# Altium schematic sheet Motor_External_Connectors.SchDoc(Motor_External_Connectors)
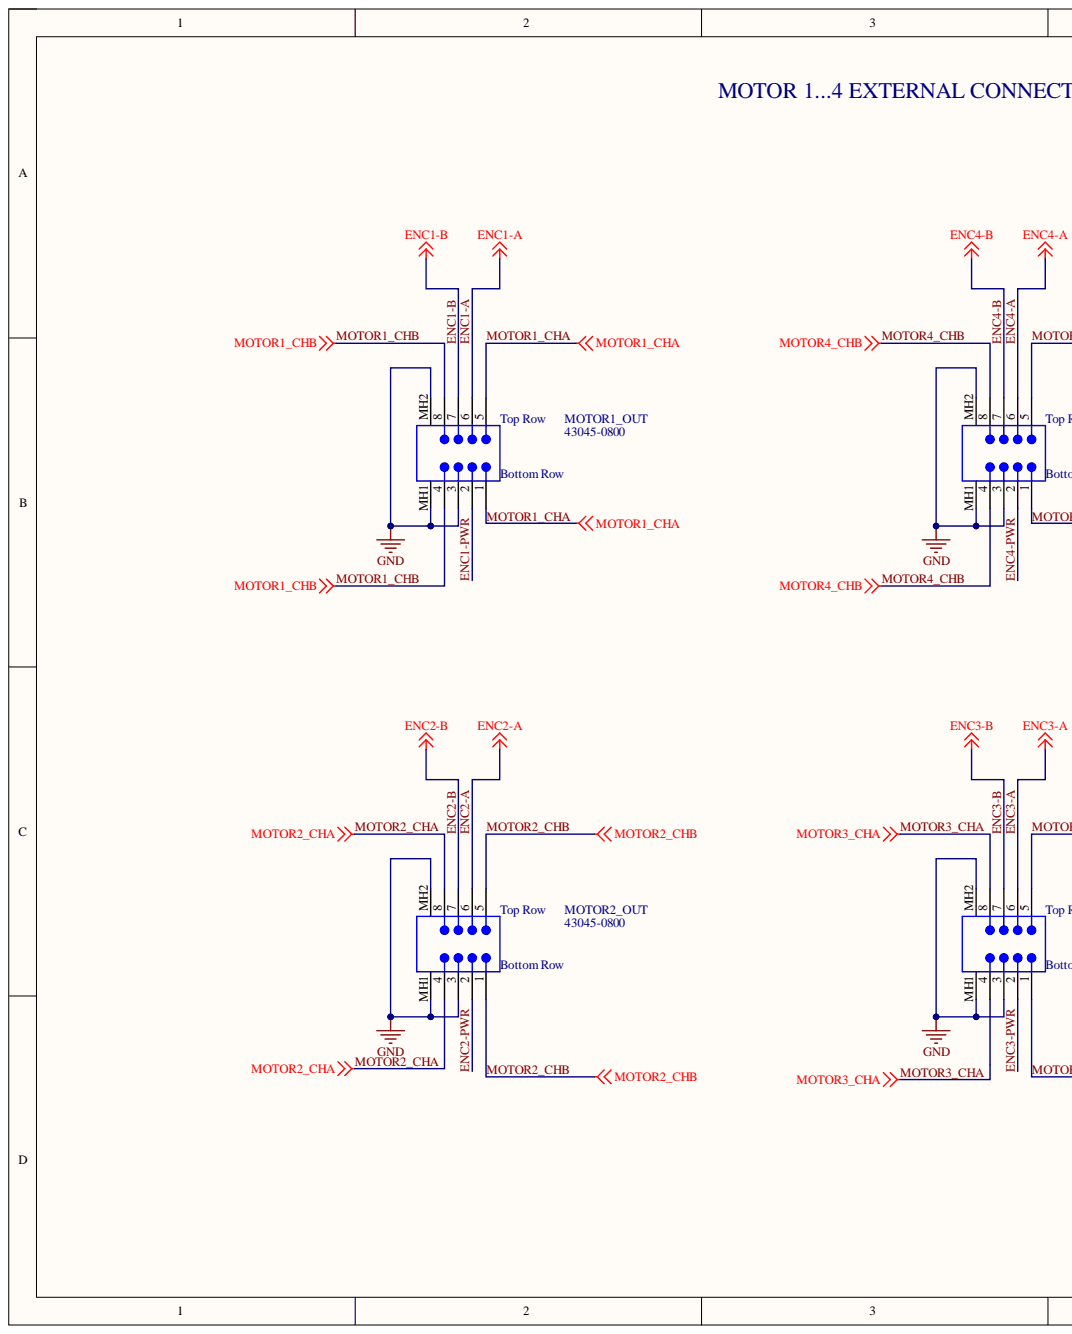
[diagram p0: Motor_External_Connectors.SchDoc, left]
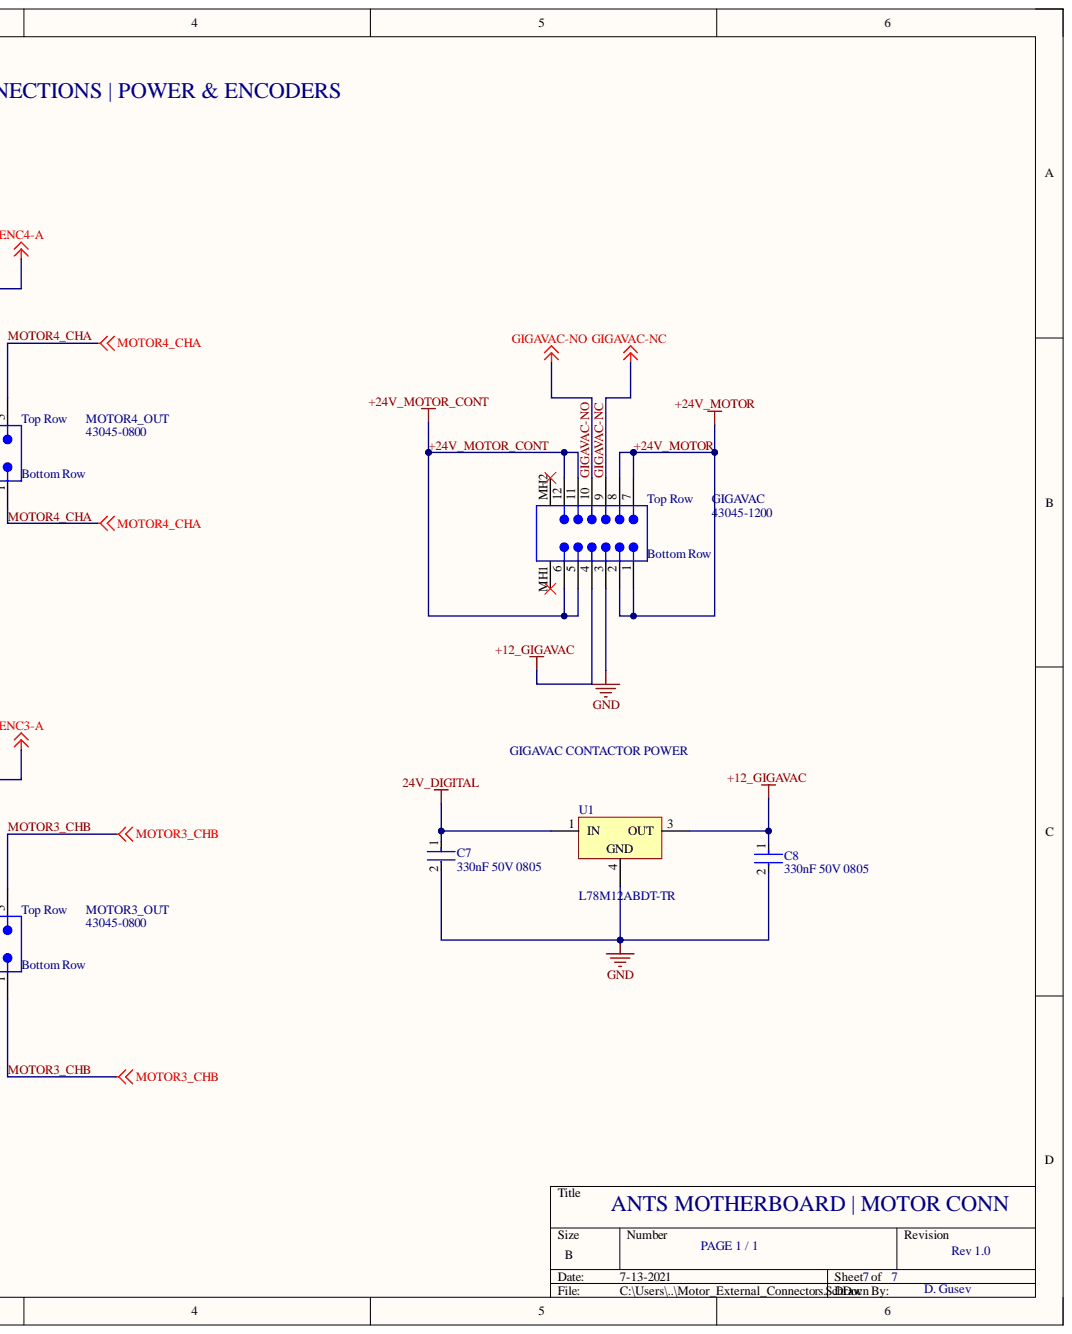
[diagram p1: Motor_External_Connectors.SchDoc, right]

## Parts
C7  330nF 50V 0805  [FP-C0805C-DN-MFG]
C8  330nF 50V 0805  [FP-C0805C-DN-MFG]
GIGAVAC  43045-1200  [MOLX-43045-1200]
MOTOR1_OUT  43045-0800  [MOLX-43045-0800]
MOTOR2_OUT  43045-0800  [MOLX-43045-0800]
MOTOR3_OUT  43045-0800  [MOLX-43045-0800]
MOTOR4_OUT  43045-0800  [MOLX-43045-0800]
U1  L78M12ABDT-TR  [TO-252_M]

## Nets
+12_GIGAVAC: C8.1 GIGAVAC.4 U1.3
GND: C7.2 C8.2 GIGAVAC.3 MOTOR1_OUT.3 MOTOR1_OUT.MH1 MOTOR1_OUT.MH2 MOTOR2_OUT.3 MOTOR2_OUT.MH1 MOTOR2_OUT.MH2 MOTOR3_OUT.3 MOTOR3_OUT.MH1 MOTOR3_OUT.MH2 MOTOR4_OUT.3 MOTOR4_OUT.MH1 MOTOR4_OUT.MH2 U1.4
24V_DIGITAL: C7.1 GIGAVAC.1 GIGAVAC.2 GIGAVAC.5 GIGAVAC.6 GIGAVAC.7 GIGAVAC.8 GIGAVAC.11 GIGAVAC.12 U1.1  [also labelled +24V_MOTOR, +24V_MOTOR_CONT]
ENC1-A: MOTOR1_OUT.6
ENC1-B: MOTOR1_OUT.7
ENC1-PWR: MOTOR1_OUT.2
ENC2-A: MOTOR2_OUT.6
ENC2-B: MOTOR2_OUT.7
ENC2-PWR: MOTOR2_OUT.2
ENC3-A: MOTOR3_OUT.6
ENC3-B: MOTOR3_OUT.7
ENC3-PWR: MOTOR3_OUT.2
ENC4-A: MOTOR4_OUT.6
ENC4-B: MOTOR4_OUT.7
ENC4-PWR: MOTOR4_OUT.2
GIGAVAC-NC: GIGAVAC.9
GIGAVAC-NO: GIGAVAC.10
MOTOR1_CHA: MOTOR1_OUT.1 MOTOR1_OUT.5
MOTOR1_CHB: MOTOR1_OUT.4 MOTOR1_OUT.8
MOTOR2_CHA: MOTOR2_OUT.4 MOTOR2_OUT.8
MOTOR2_CHB: MOTOR2_OUT.1 MOTOR2_OUT.5
MOTOR3_CHA: MOTOR3_OUT.4 MOTOR3_OUT.8
MOTOR3_CHB: MOTOR3_OUT.1 MOTOR3_OUT.5
MOTOR4_CHA: MOTOR4_OUT.1 MOTOR4_OUT.5
MOTOR4_CHB: MOTOR4_OUT.4 MOTOR4_OUT.8

Not connected: GIGAVAC MH1 MH2

## Library data of the parts
C7 C8 = CMP-1036-04607-3: CAP CER 0.33UF 50V X7R 0805; Manufacturer: KEMET; Manufacturer Part Number: C0805C334K5RACTU; Capacitance: 0.33 μF; Material: Ceramic; Temperature Coefficient: 30ppm/°C; Tolerance: 0.1; Value: 0.33 μF; Automotive: No; Category: Cap; Component Kind: Standard; ComponentLink2Description: Datasheet; ComponentLink2URL: https://content.kemet.com/datasheets/KEM_C1003_C0G_SMD.pdf; Device Class L1: Passive Components; Device Class L2: Capacitors; Device Class L3: Ceramic Capacitors; Digikey Description: CAP CER 0.33UF 50V X7R 0805; Height: 0.88mm; Ipc Land Pattern Name: CAPC200125X78; Lead Free: Yes; Library Name: Altium Content Vault; Mouser Description: Multilayer Ceramic Capacitors MLCC - SMD/SMT 50volts 0.33uF 10% X7R; Octopart: https://octopart.com/c0805c334k5ractu-kemet-10475704; Package: C0805C-DN; RoHS: TRUE; Temperature: -55°C to +125°C; Temperature Characteristic: X7R; Temperature Range High: +125°C; Temperature Range Low: -55°C; Voltage Rating: 50 V
GIGAVAC = Molex 0430451-200: 3 mm Pitch Micro-Fit 3.0 Connector, 17.27 mm Mated Height, Vertical, Through Hole, 12 Circuits, -40 to 105 degC, RoHS, Tray; PartNumber: 43045-0812; No. of Positions: 8; No. of Rows: 2; Pitch: 3 mm; Component Kind: Standard; ComponentLink1Description: Manufacturer URL; ComponentLink1URL: http://www.molex.com/; ComponentLink2Description: Datasheet; ComponentLink2URL: http://www.molex.com/webdocs/datasheets/pdf/en-us/0430450812_PCB_HEADERS.pdf; ComponentLink3Description: Package Specification; ComponentLink3URL: http://www.molex.com/pdm_docs/sd/430450812_sd.pdf; Mounting Technology: Through Hole; Orientation: Vertical; PackageDescription: 8-Pin PCB Header, Vertical, Pitch 3 mm, Mated Height 17.27 mm, Through Hole; PackageVersion: Rev. F, 06/2009; Packing: Tray; RoHS: TRUE; Temperature Max: 105 degC; Temperature Min: -40 degC; Library Name: ANTS-MotherBoard-B1.SchLib
MOTOR1_OUT MOTOR2_OUT MOTOR3_OUT MOTOR4_OUT = Molex 0430450-800: 3 mm Pitch Micro-Fit 3.0 Connector, 17.27 mm Mated Height, Vertical, Through Hole, 8 Circuits, -40 to 105 degC, RoHS, Tray; PartNumber: 43045-0812; No. of Positions: 8; No. of Rows: 2; Pitch: 3 mm; Component Kind: Standard; ComponentLink1Description: Manufacturer URL; ComponentLink1URL: http://www.molex.com/; ComponentLink2Description: Datasheet; ComponentLink2URL: http://www.molex.com/webdocs/datasheets/pdf/en-us/0430450812_PCB_HEADERS.pdf; ComponentLink3Description: Package Specification; ComponentLink3URL: http://www.molex.com/pdm_docs/sd/430450812_sd.pdf; Mounting Technology: Through Hole; Orientation: Vertical; PackageDescription: 8-Pin PCB Header, Vertical, Pitch 3 mm, Mated Height 17.27 mm, Through Hole; PackageVersion: Rev. F, 06/2009; Packing: Tray; RoHS: TRUE; Temperature Max: 105 degC; Temperature Min: -40 degC; Library Name: ANTS-MotherBoard-B1.SchLib
U1 = CMP-0244-00186-1: Precision 500mA Regulator, 12V, 3-Pin DPAK, Tape and Reel; Component Kind: Standard; ComponentLink1Description: Manufacturer URL; ComponentLink1URL: http://www.st.com; ComponentLink2Description: Datasheet; ComponentLink2URL: http://www.st.com/internet/com/TECHNICAL_RESOURCES/TECHNICAL_LITERATURE/DATASHEET/CD00000448.pdf; DatasheetVersion: 1/11/2010; Library Name: Altium Content Vault; PackageDescription: 3-Pin Transistor Outline Package 2.28 mm Pitch, 9.7 mm Lead Span (DPAK); PackageReference: TO-252; PackageVersion: 2011
